# Altium schematic sheet Conectores.SchDoc
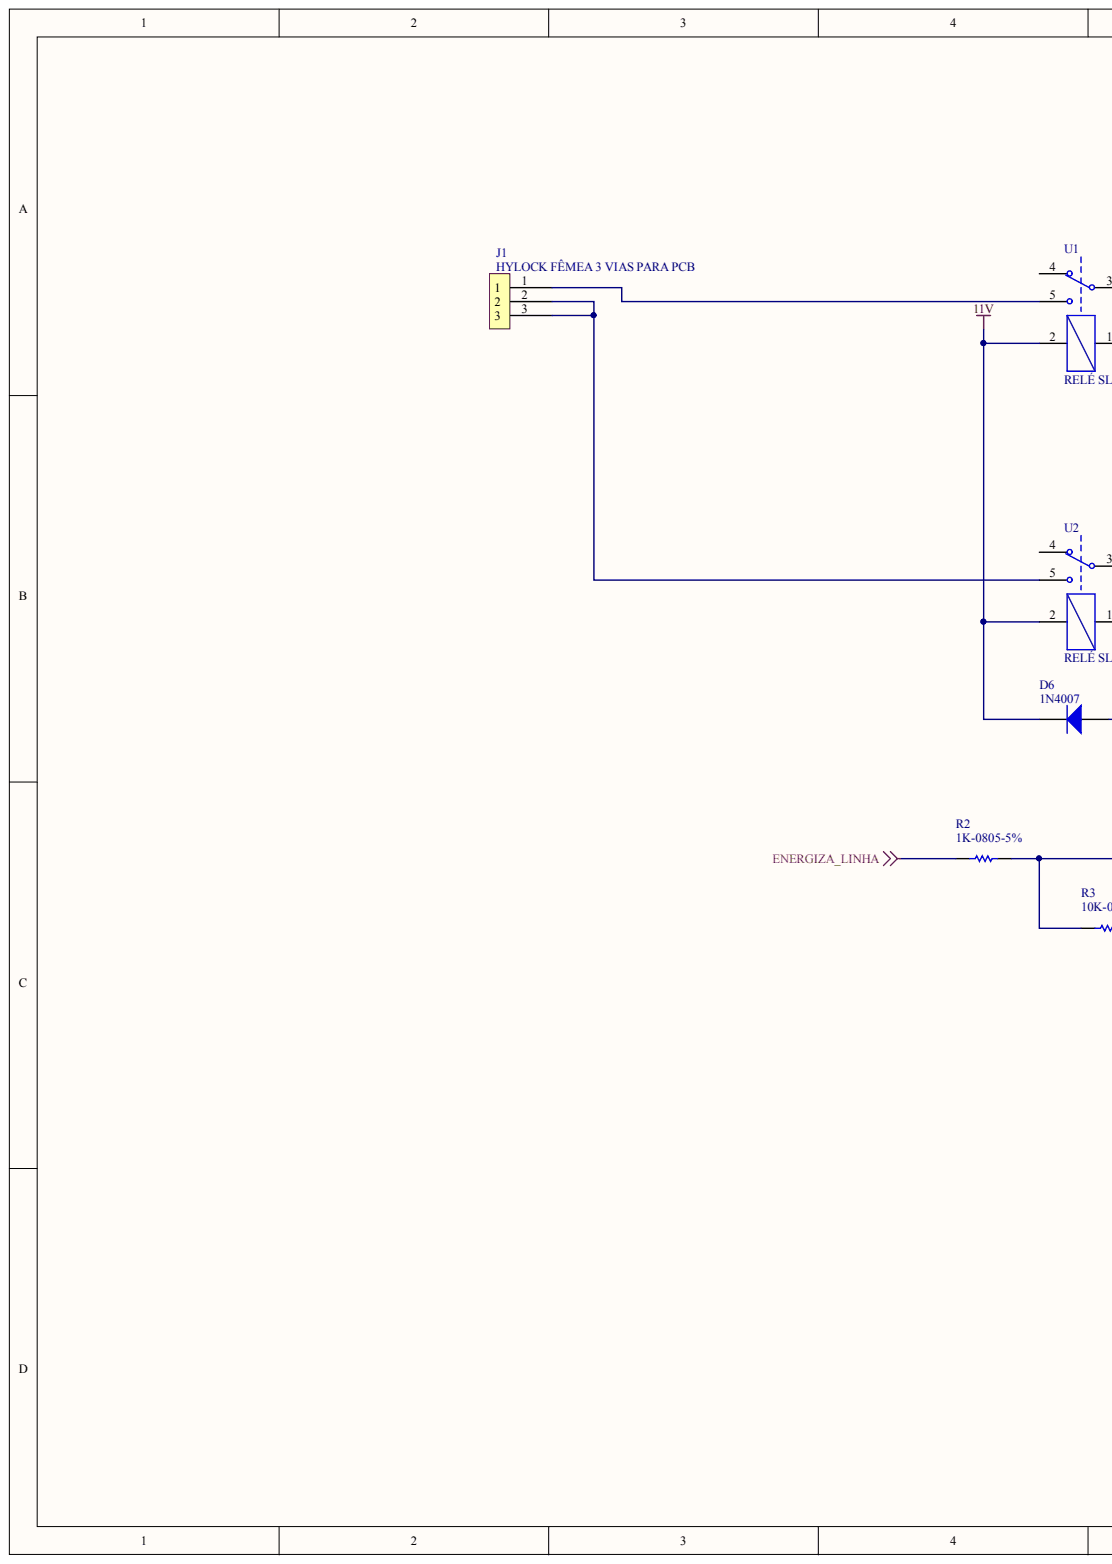
[diagram p0: Conectores.SchDoc, left]
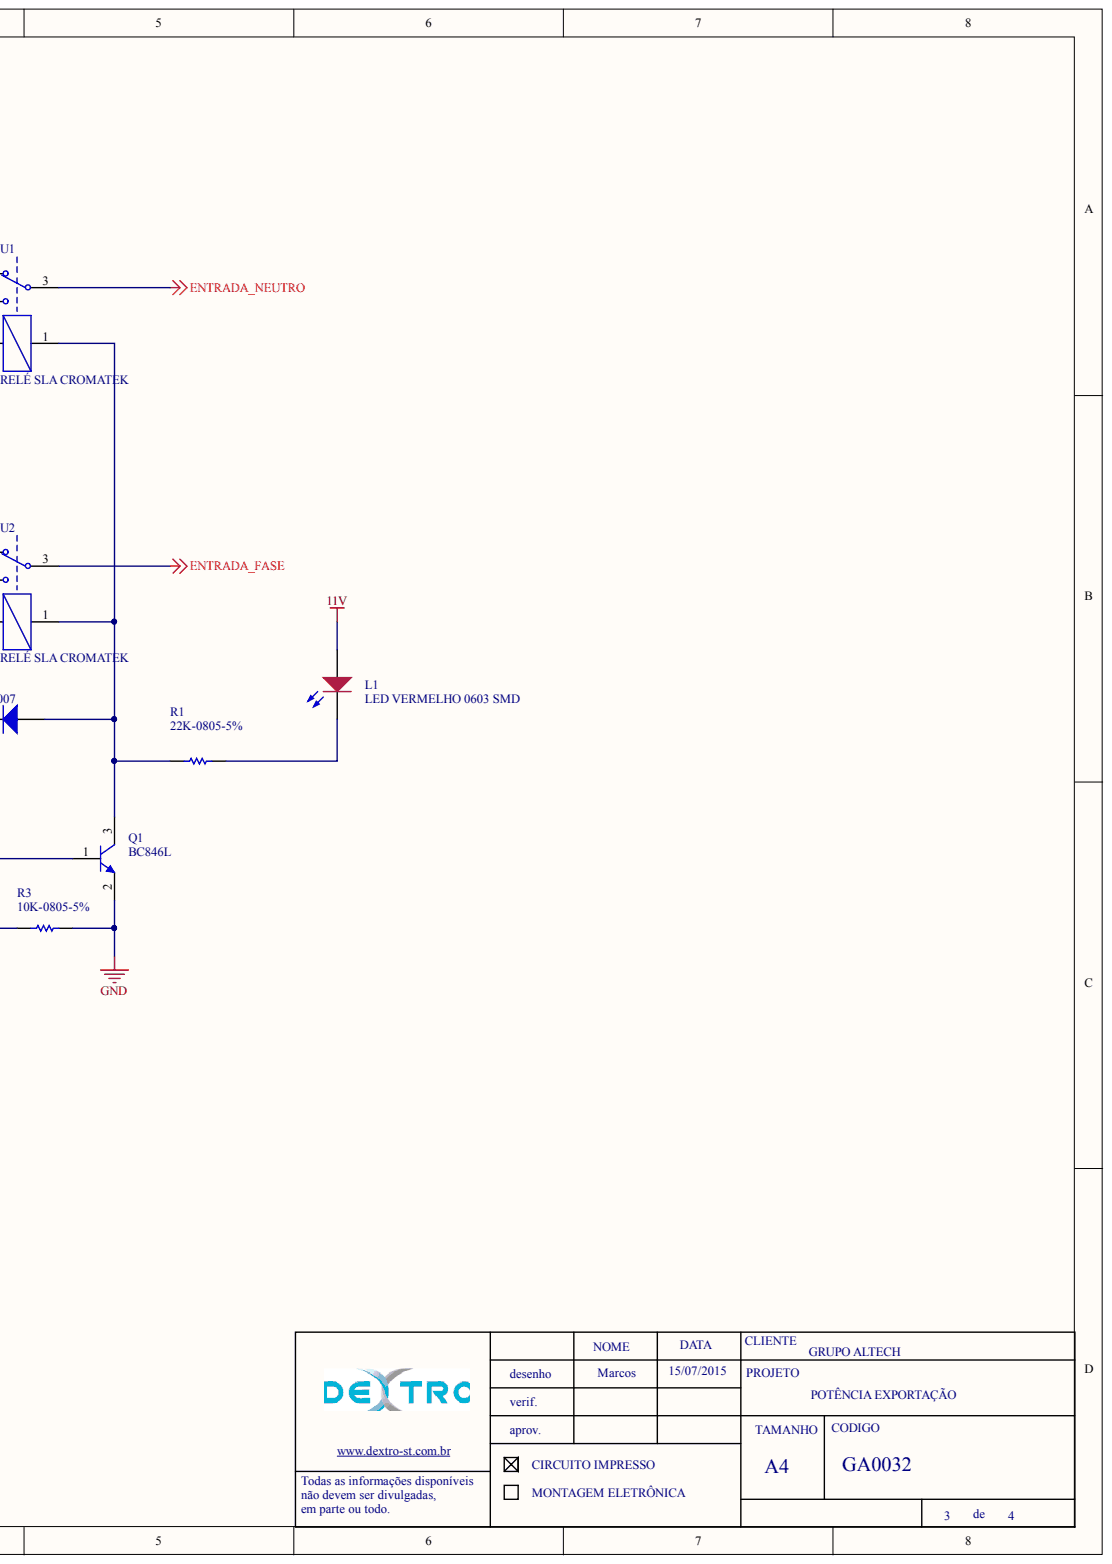
[diagram p1: Conectores.SchDoc, right]

## Parts
D6  1N4007  [SMA DIODES]
J1  HYLOCK FÊMEA 3 VIAS PARA PCB  [CONECTOR HYLOCK 3 VIAS]
L1  LED VERMELHO 0603 SMD  [LED 1206]
Q1  BC846L  [SOT23 - REVISADO ONDA]
R1  22K-0805-5%  [0805-REVISADO ONDA]
R2  1K-0805-5%  [0805-REVISADO ONDA]
R3  10K-0805-5%  [0805-REVISADO ONDA]
U1  RELÉ SLA CROMATEK  [RELE SLA CROMATEK]
U2  RELÉ SLA CROMATEK  [RELE SLA CROMATEK]

## Nets
11V: D6.2 L1.1 U1.2 U2.2
ENERGIZA_LINHA: R2.1
ENTRADA_FASE: U2.3
ENTRADA_NEUTRO: U1.3
GND: Q1.2 R3.2
NetD6_1: D6.1 Q1.3 R1.1 U1.1 U2.1
NetJ1_1: J1.1 U1.5
NetJ1_2: J1.2 J1.3 U2.5
NetL1_2: L1.2 R1.2
NetQ1_1: Q1.1 R2.2 R3.1

Not connected: U1 4; U2 4

## Library data of the parts
J1 = PCMC2-3: Component Kind: Standard; Description: PCMC2-3; Library Name: AquinoLib.SchLib
Q1 = BC846: Component Kind: Standard; Description: BC846L; ERP: 106.22.001; Library Name: AquinoLib.SchLib
R2 = 10K-0805-5%: Component Kind: Standard; Description: 10K-0805-5%; ERP: 102.11.054; Library Name: AquinoLib.SchLib
D6 = 1N4007: Component Kind: Standard; Description: 1N4007; ERP: 120.18.001; Library Name: AquinoLib.SchLib
L1 = LED VERMELHO 0603 SMD: Component Kind: Standard; Description: LED VERMELHO 0603 SMD; ERP: 124.02.010; Library Name: AquinoLib.SchLib
R1 = 22K-0805-5%: Component Kind: Standard; Description: 22K-0805-5%; ERP: 102.11.058; Library Name: AquinoLib.SchLib
R3 = 10K-0805-5%: Component Kind: Standard; Description: 10K-0805-5%; ERP: 102.11.054; Library Name: AquinoLib.SchLib
U1 U2 = RELÉ SLA CROMATEK: Component Kind: Standard; Library Name: AquinoLib.SchLib
